# Altium schematic sheet FPGA_INTERFACE.SchDoc(FPGA_INTERFACE)
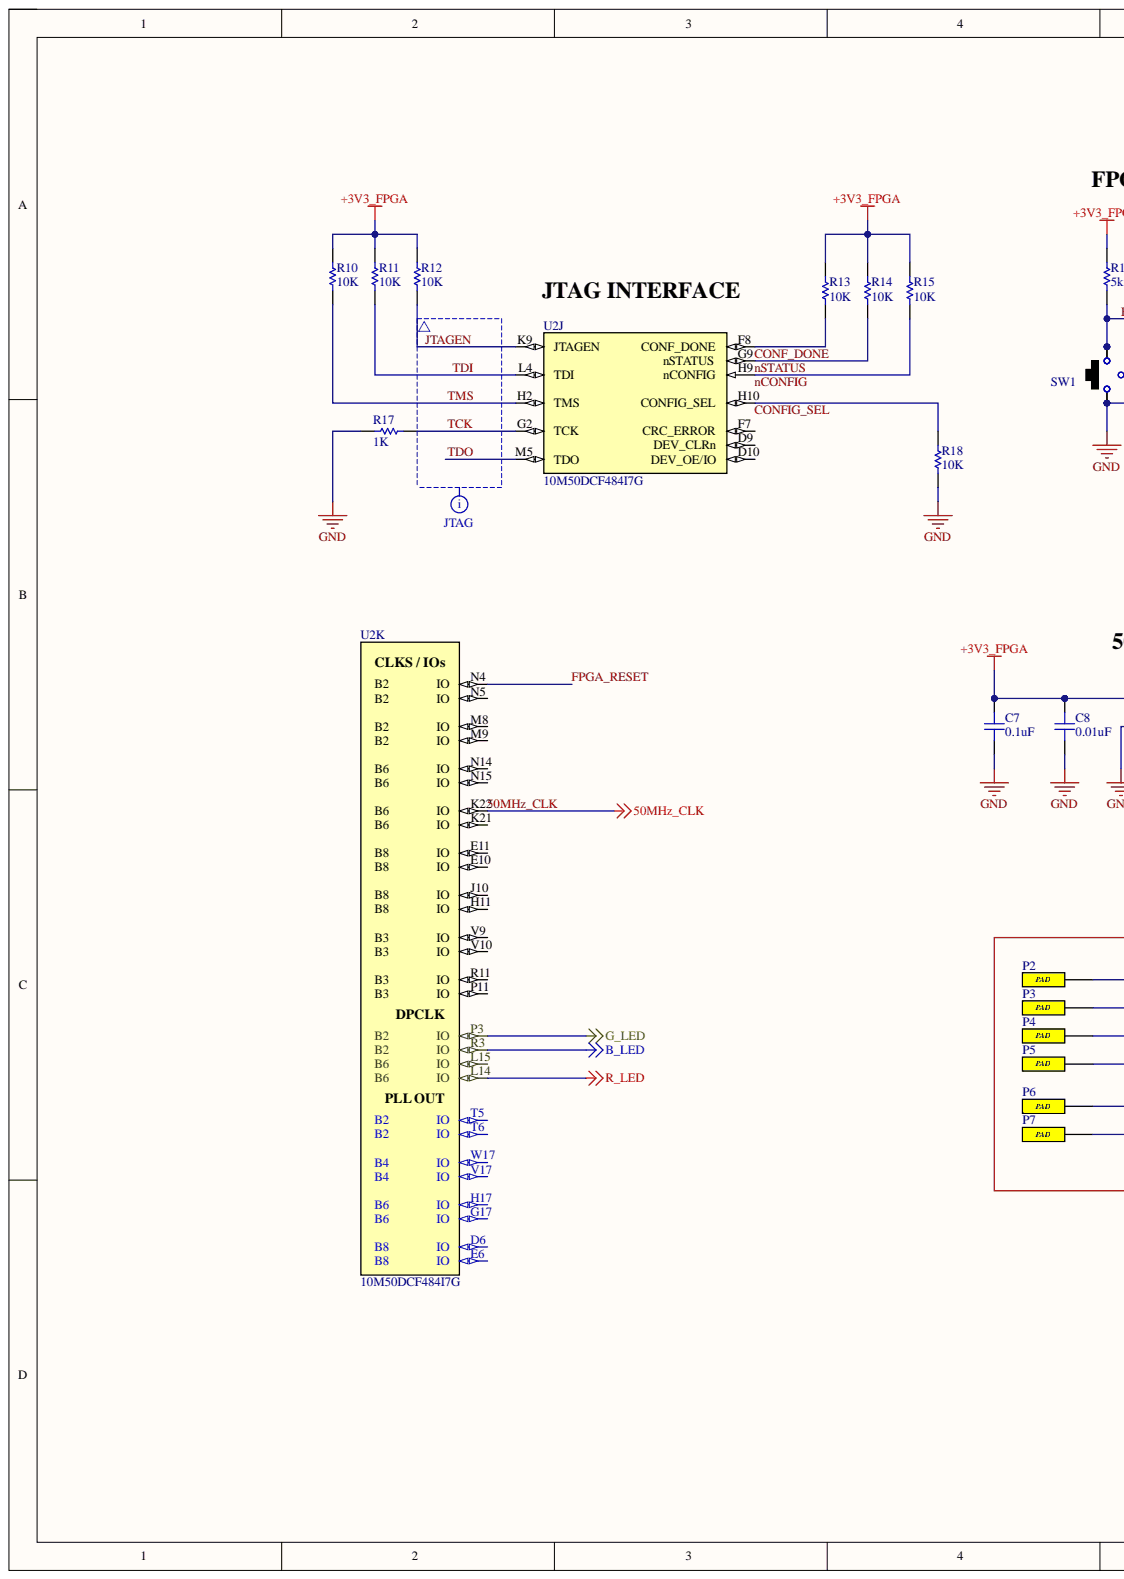
[diagram p0: FPGA_INTERFACE.SchDoc, left]
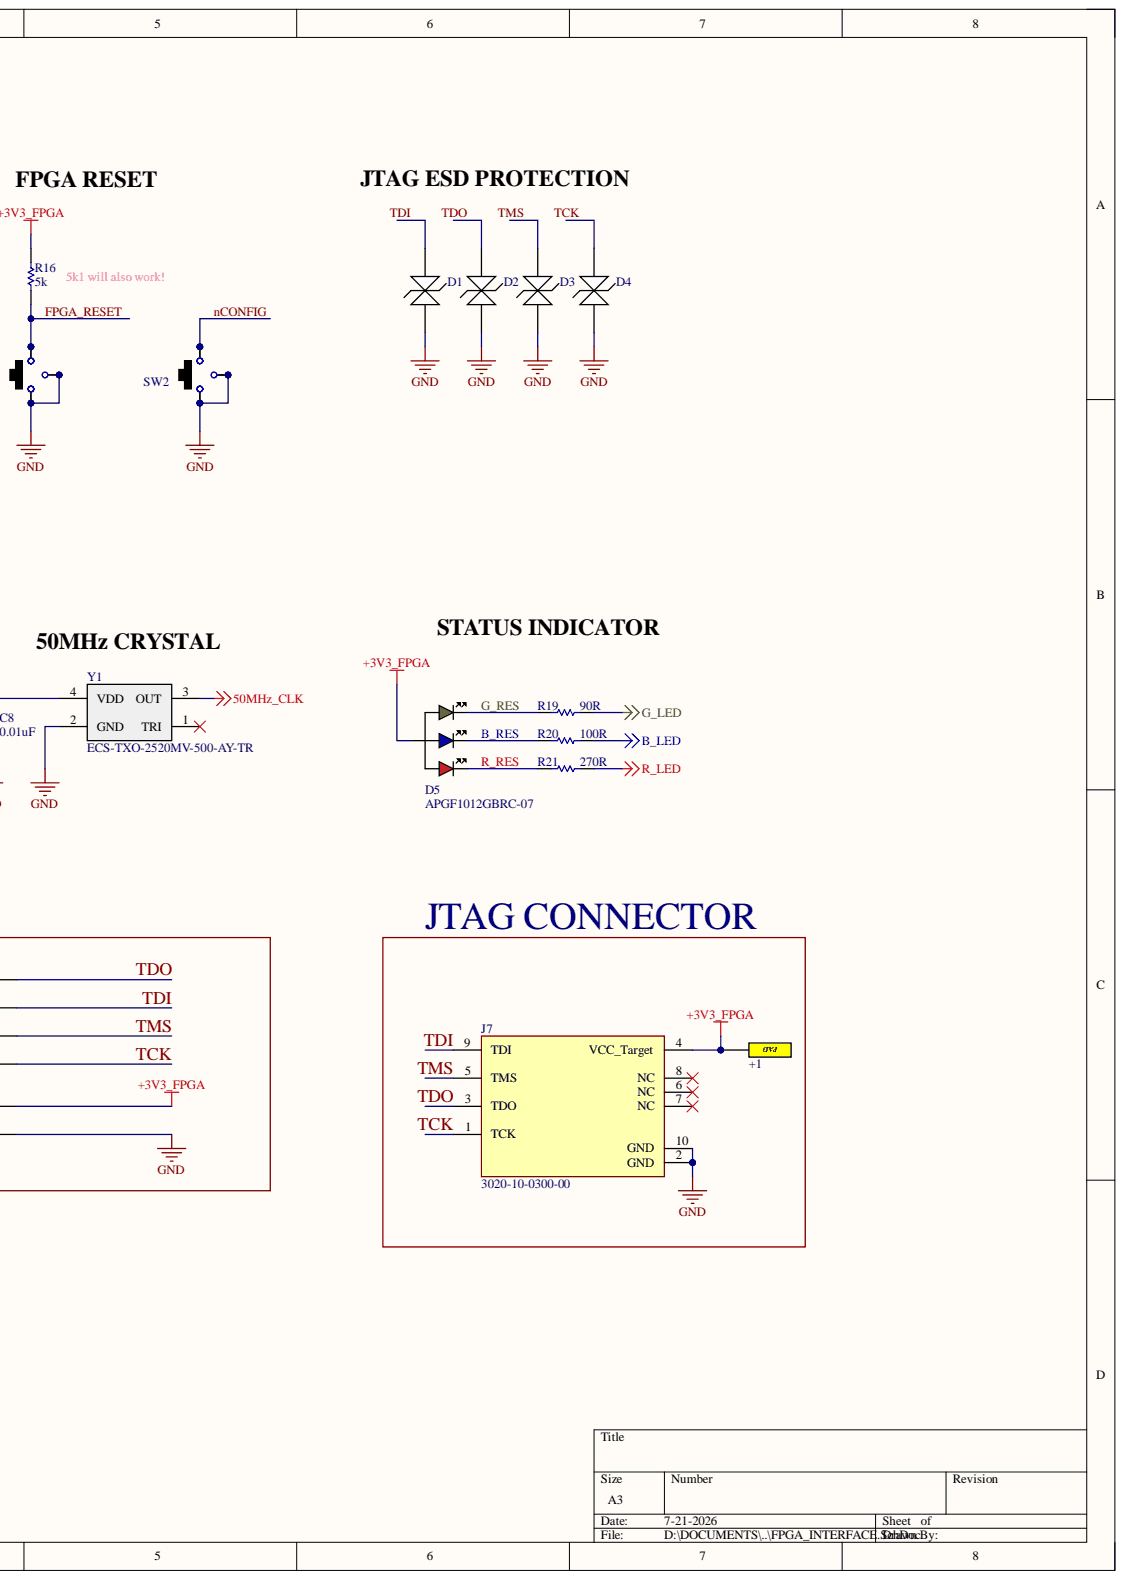
[diagram p1: FPGA_INTERFACE.SchDoc, right]

## Parts
+1  PAD_SMD_1.5x1mm  [PAD 1.5 X 1]  (value not drawn)
C7  0.1uF  [CAP_0402]
C8  0.01uF  [CAP_0402]
D1  CG0402MLU-3.3G  [VARC1005X41N]  (value not drawn)
D2  CG0402MLU-3.3G  [VARC1005X41N]  (value not drawn)
D3  CG0402MLU-3.3G  [VARC1005X41N]  (value not drawn)
D4  CG0402MLU-3.3G  [VARC1005X41N]  (value not drawn)
D5  APGF1012GBRC-07  [APGF1012GBRC07]
J7  3020-10-0300-00  [3020-10-0300-00]
P2  PAD_SMD_1.5x1mm  [PAD 1.5 X 1]  (value not drawn)
P3  PAD_SMD_1.5x1mm  [PAD 1.5 X 1]  (value not drawn)
P4  PAD_SMD_1.5x1mm  [PAD 1.5 X 1]  (value not drawn)
P5  PAD_SMD_1.5x1mm  [PAD 1.5 X 1]  (value not drawn)
P6  PAD_SMD_1.5x1mm  [PAD 1.5 X 1]  (value not drawn)
P7  PAD_SMD_1.5x1mm  [PAD 1.5 X 1]  (value not drawn)
R10  10K  [RES_0402]
R11  10K  [RES_0402]
R12  10K  [RES_0402]
R13  10K  [RES_0402]
R14  10K  [RES_0402]
R15  10K  [RES_0402]
R16  5k  [RES_0402]
R17  1K  [RES_0402]
R18  10K  [RES_0402]
R19  90R  [RES_0402]
R20  100R  [RES_0402]
R21  270R  [RES_0402]
SW1  435151014824  [435151014824]  (value not drawn)
SW2  435151014824  [435151014824]  (value not drawn)
U2  10M50DCF484I7G  [BGA484C100P22X22_2300X2300X200]  (parts J,K)
Y1  ECS-TXO-2520MV-500-AY-TR  [ECSTXO2520MV500AYTR]

## Nets
+3V3_FPGA: +1.1 C7.2 C8.2 D5.1 J7.4(VCC_Target) P6.1 R10.1 R11.1 R12.1 R13.1 R14.1 R15.1 R16.2 Y1.4(VDD)
B_LED: R20.2 U2.R3(IO)
G_LED: R19.2 U2.P3(IO)
GND: C7.1 C8.1 D1.2 D2.2 D3.2 D4.2 J7.2(GND) J7.10(GND) P7.1 R17.1 R18.2 SW1.1 SW1.2 SW1.5 SW1.6 SW2.1 SW2.2 SW2.5 SW2.6 Y1.2(GND)
R_LED: R21.2 U2.L14(IO)
50MHz_CLK: U2.K22(IO) Y1.3(OUT)
B_RES: D5.3 R20.1
CONF_DONE: R13.2 U2.F8(CONF_DONE)
CONFIG_SEL: R18.1 U2.H10(CONFIG_SEL)
FPGA_RESET: R16.1 SW1.3 SW1.4 U2.N4(IO)
G_RES: D5.2 R19.1
JTAGEN: R12.2 U2.K9(JTAGEN)
nCONFIG: R15.2 SW2.3 SW2.4 U2.H9(nCONFIG)
nSTATUS: R14.2 U2.G9(nSTATUS)
R_RES: D5.4 R21.1
TCK: D4.1 J7.1(TCK) P5.1 R17.2 U2.G2(TCK)
TDI: D1.1 J7.9(TDI) P3.1 R11.2 U2.L4(TDI)
TDO: D2.1 J7.3(TDO) P2.1 U2.M5(TDO)
TMS: D3.1 J7.5(TMS) P4.1 R10.2 U2.H2(TMS)

Not connected: J7 6(NC) 7(NC) 8(NC); U2 D6(IO) D9(DEV_CLRn) D10(DEV_OE/IO) E6(IO) E10(IO) E11(IO) F7(CRC_ERROR) G17(IO) H11(IO) H17(IO) J10(IO) K21(IO) L15(IO) M8(IO) M9(IO) N5(IO) N14(IO) N15(IO) P11(IO) R11(IO) T5(IO) T6(IO) V9(IO) V10(IO) V17(IO) W17(IO); Y1 1(TRI)

## Library data of the parts
+1 P2-P7 = PAD_SMD_1.5x1mm: Testpoint; Component Kind: Standard
  P2-P7: Library Name: MAX10.SchLib
  +1: Library Name: ANAND_LIB.SchLib
C7 C8 = CAP_0402_25V: C0402_25V; Component Kind: Standard; Library Name: MAX10.SchLib
D1-D4 = CG0402MLU-3.3G: ESD Diode; Manufacturer_Name: Bourns; Note: Not a TVS Diode!; Component Kind: Standard; Datasheet Link: https://www.bourns.com/docs/Product-Datasheets/MLU.pdf; Height: 0.41mm; Library Name: FPGA_LIB.SchLib; MPN: CG0402MLU-3.3G
D5 = APGF1012GBRC-07: RGB LED; Manufacturer_Name: Kingbright; Component Kind: Standard; Datasheet Link: https://www.kingbrightusa.com/images/catalog/SPEC/APGF1012GBRC-07.pdf; Height: 0.3mm; Library Name: FPGA_LIB.SchLib; Mouser Part Number: 604-APGF1012GBRC-07; Mouser Price/Stock: https://www.mouser.co.uk/ProductDetail/Kingbright/APGF1012GBRC-07?qs=aP1CjGhiNiHAQm9ZxbLXkg%3D%3D; MPN: APGF1012GBRC-07
J7 = 3020-10-0300-00: IDC BOX HEADER, 2.54MM; Component Kind: Standard; MPN: 3020-10-0300-00; Library Name: ANAND_LIB.SchLib
R10-R15 R17 R18 R20 = RES_0402: R0402; Component Kind: Standard; Library Name: FPGA_LIB.SchLib
R16 = RES_0402: R0402; MPN: ERJ-2RKF5101X; Component Kind: Standard; Library Name: FPGA_LIB.SchLib
R19 = RES_0402: R0402; MPN: RP73PF1E90R9BTDF; Component Kind: Standard; Library Name: FPGA_LIB.SchLib
R21 = RES_0402: R0402; MPN: TNPW0402270RBEED; Component Kind: Standard; Library Name: FPGA_LIB.SchLib
SW1 SW2 = 435151014824: Tactile; Manufacturer_Name: Wurth Elektronik; Component Kind: Standard; Datasheet Link: https://componentsearchengine.com/Datasheets/2/435151014824.pdf; Height: 1.4mm; Library Name: FPGA_LIB.SchLib; Mouser Part Number: 710-435151014824; Mouser Price/Stock: https://www.mouser.co.uk/ProductDetail/Wurth-Elektronik/435151014824?qs=OlC7AqGiEDkCCtReGm3ZVw%3D%3D; MPN: 435151014824
U2 = 10M50DCF484I7G: 360 IO FPGA; Manufacturer_Name: Intel; Pitch: 1mm; Component Kind: Standard; Datasheet Link: https://componentsearchengine.com/Datasheets/1/10M40DCF484C8G.pdf; Height: 2mm; Library Name: FPGA_LIB.SchLib; MPN: 10M50DCF484I7G
Y1 = ECS-TXO-2520MV-500-AY-TR: Oscillator; Manufacturer_Name: ECS; Component Kind: Standard; Datasheet Link: https://datasheet.datasheetarchive.com/originals/distributors/Datasheets_SAMA/9e46f9ac7e543dafdbf4457b18198e46.pdf; Height: 1mm; Library Name: FPGA_LIB.SchLib; Manufacturer_Part_Number: ECS-TXO-2520MV-500-AY-TR; Mouser Part Number: 520-TXO2520MV500AYTR; Mouser Price/Stock: https://www.mouser.co.uk/ProductDetail/ECS/ECS-TXO-2520MV-500-AY-TR?qs=bAKSY%2FctAC78DAF65%252BjqVA%3D%3D
